# Altium schematic sheet Camera.SchDoc
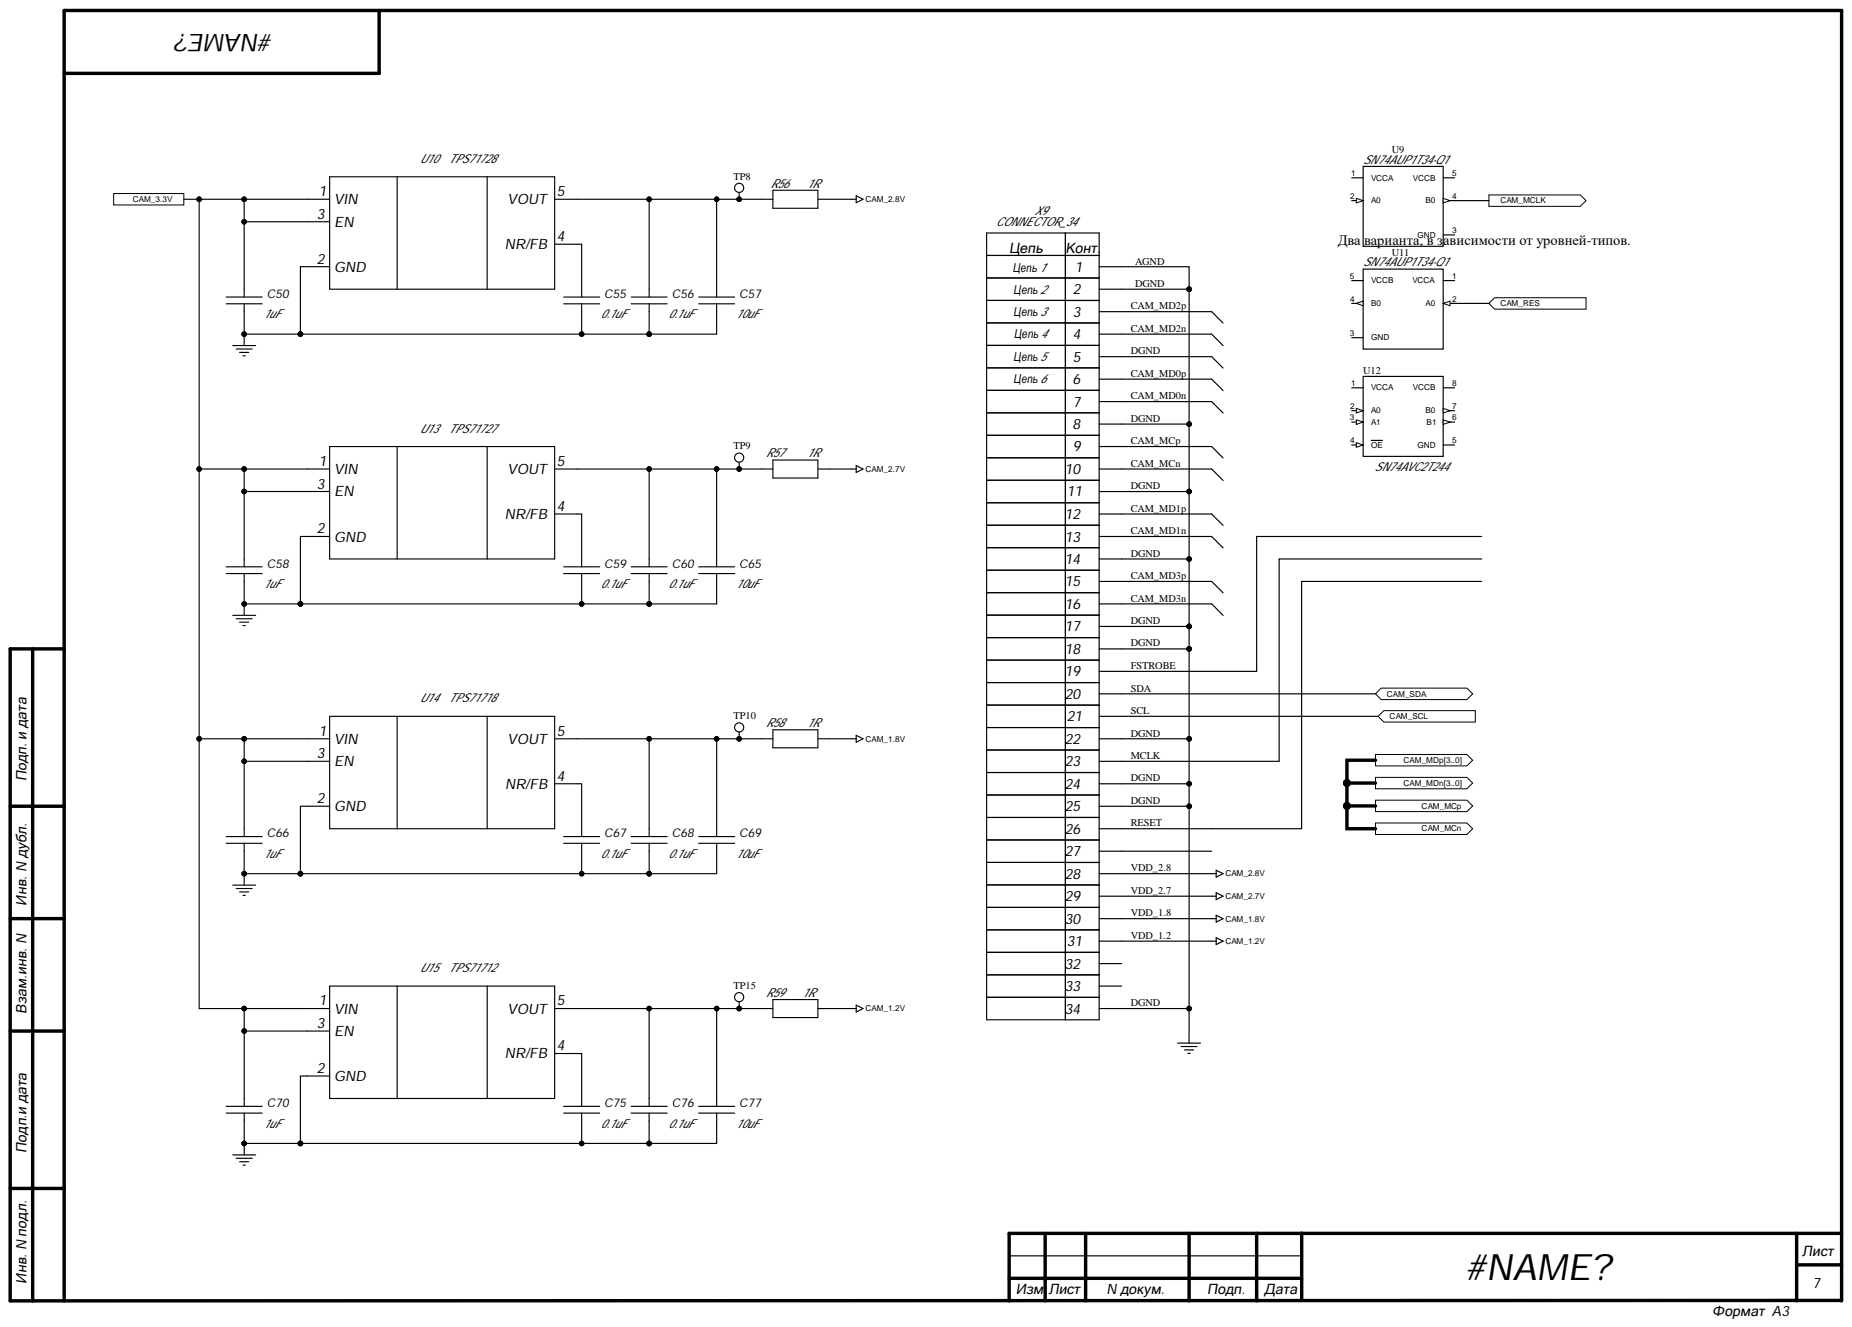
[diagram p0: Camera.SchDoc]

## Parts
C50  value 1uF  (hidden comment: Cap)
C55  value 0.1uF  (hidden comment: Cap)
C56  value 0.1uF  (hidden comment: Cap)
C57  value 10uF  (hidden comment: Cap)
C58  value 1uF  (hidden comment: Cap)
C59  value 0.1uF  (hidden comment: Cap)
C60  value 0.1uF  (hidden comment: Cap)
C65  value 10uF  (hidden comment: Cap)
C66  value 1uF  (hidden comment: Cap)
C67  value 0.1uF  (hidden comment: Cap)
C68  value 0.1uF  (hidden comment: Cap)
C69  value 10uF  (hidden comment: Cap)
C70  value 1uF  (hidden comment: Cap)
C75  value 0.1uF  (hidden comment: Cap)
C76  value 0.1uF  (hidden comment: Cap)
C77  value 10uF  (hidden comment: Cap)
R56  value 1R  (hidden comment: Res2)
R57  value 1R  (hidden comment: Res2)
R58  value 1R  (hidden comment: Res2)
R59  value 1R  (hidden comment: Res2)
TP8  (no value)  [TP_0MM5_1MM0]  (no BOM)
TP9  (no value)  [TP_0MM5_1MM0]  (no BOM)
TP10  (no value)  [TP_0MM5_1MM0]  (no BOM)
TP15  (no value)  [TP_0MM5_1MM0]  (no BOM)
U9  SN74AUP1T34-Q1  [SC70-5]
U10  value TPS71728  [SOT23_5]  (hidden comment: TPS71733)
U11  SN74AUP1T34-Q1  [SC70-5]
U12  SN74AVC2T244  [DQM]
U13  value TPS71727  [SOT23_5]  (hidden comment: TPS71733)
U14  value TPS71718  [SOT23_5]  (hidden comment: TPS71733)
U15  value TPS71712  [SOT23_5]  (hidden comment: TPS71733)
X9  CONNECTOR_34  Circuit 1: Цепь 1  Circuit 2: Цепь 2  Circuit 3: Цепь 3  Circuit 4: Цепь 4  Circuit 5: Цепь 5  Circuit 6: Цепь 6

## Ports
CAM_3.3V, CAM_MCLK, CAM_MCn, CAM_MCp, CAM_MDn0, CAM_MDn1, CAM_MDn2, CAM_MDn3, CAM_MDn[3..0], CAM_MDp0, CAM_MDp1, CAM_MDp2, CAM_MDp3, CAM_MDp[3..0], CAM_RES, CAM_SCL, CAM_SDA

## Nets
GND: C50.2 C55.2 C56.2 C57.2 C58.2 C59.2 C60.2 C65.2 C66.2 C67.2 C68.2 C69.2 C70.2 C75.2 C76.2 C77.2 U10.2(GND) U13.2(GND) U14.2(GND) U15.2(GND)
AGND: X9.1 X9.2 X9.5 X9.8 X9.11 X9.14 X9.17 X9.18 X9.22 X9.24 X9.25 X9.34
CAM_3.3V: C50.1 C58.1 C66.1 C70.1 U10.1(VIN) U10.3(EN) U13.1(VIN) U13.3(EN) U14.1(VIN) U14.3(EN) U15.1(VIN) U15.3(EN) ; port CAM_3.3V
CAM_MCLK: U9.4(B0) ; port CAM_MCLK
CAM_MCn: X9.10
CAM_MCp: X9.9
CAM_MD0n: X9.7
CAM_MD0p: X9.6
CAM_MD1n: X9.13
CAM_MD1p: X9.12
CAM_MD2n: X9.4
CAM_MD2p: X9.3
CAM_MD3n: X9.16
CAM_MD3p: X9.15
CAM_RES: U11.2(A0) ; port CAM_RES
FSTROBE: X9.19
MCLK: X9.23
RESET: X9.26
SCL: X9.21 ; port CAM_SCL
SDA: X9.20 ; port CAM_SDA
VDD_1.2: R59.2 X9.31
VDD_1.8: R58.2 X9.30
VDD_2.7: R57.2 X9.29
VDD_2.8: R56.2 X9.28
NetC55_1: C55.1 U10.4(NR/FB)
NetC56_1: C56.1 C57.1 R56.1 TP8.1 U10.5(VOUT)
NetC59_1: C59.1 U13.4(NR/FB)
NetC60_1: C60.1 C65.1 R57.1 TP9.1 U13.5(VOUT)
NetC67_1: C67.1 U14.4(NR/FB)
NetC68_1: C68.1 C69.1 R58.1 TP10.1 U14.5(VOUT)
NetC75_1: C75.1 U15.4(NR/FB)
NetC76_1: C76.1 C77.1 R59.1 TP15.1 U15.5(VOUT)
N00001_0: ; port CAM_MDn[3..0], port CAM_MDp[3..0]
N00001_1: ; port CAM_MDn[3..0], port CAM_MDp[3..0]
N00001_2: ; port CAM_MDn[3..0], port CAM_MDp[3..0]
N00001_3: ; port CAM_MDn[3..0], port CAM_MDp[3..0]
N00002: ; port CAM_MCp
N00003: ; port CAM_MCn

Not connected: U9 1(VCCA) 2(A0) 3(GND) 5(VCCB); U11 1(VCCA) 3(GND) 4(B0) 5(VCCB); U12 1(VCCA) 2(A0) 3(A1) 4(OE) 5(GND) 6(B1) 7(B0) 8(VCCB); X9 27 33

## Library data of the parts
C50 C55-C60 C65-C70 C75-C77 = Cap: Конденсатор; Component Kind: Standard; PackageReference: 0603; Signal Integrity: Cap; Library Name: Terra.SCHLIB
R56-R59 = Res2: Резистор; Component Kind: Standard; PackageReference: 0603; Signal Integrity: Res; Library Name: Terra.SCHLIB
TP8-TP10 TP15 = Test_Point: Тестовая точка на плате; MarkPCB: +1.8V
U9 U11 = SN74AUP1T34-Q1: Преобразователь уровня; Component Kind: Standard; Library Name: common.SCHLIB; PackageReference: SC70; Value: SN74AUP1T34-Q1
U10 U13-U15 = TPS71701: Линейный стабилизатор +1.8В; Component Kind: Standard; PackageReference: SC70-5; Library Name: AVRlinux.SCHLIB
U12 = SN74AVC2T244: Преобразователь уровня; Component Kind: Standard; Library Name: common.SCHLIB; PackageReference: DQE/DQ; Value: SN74AVC2T244
X9 = CONNECTOR_34: Component Kind: Standard; System: connector; Library Name: Terra.SCHLIB
